# Altium schematic sheet Sheet1.SchDoc
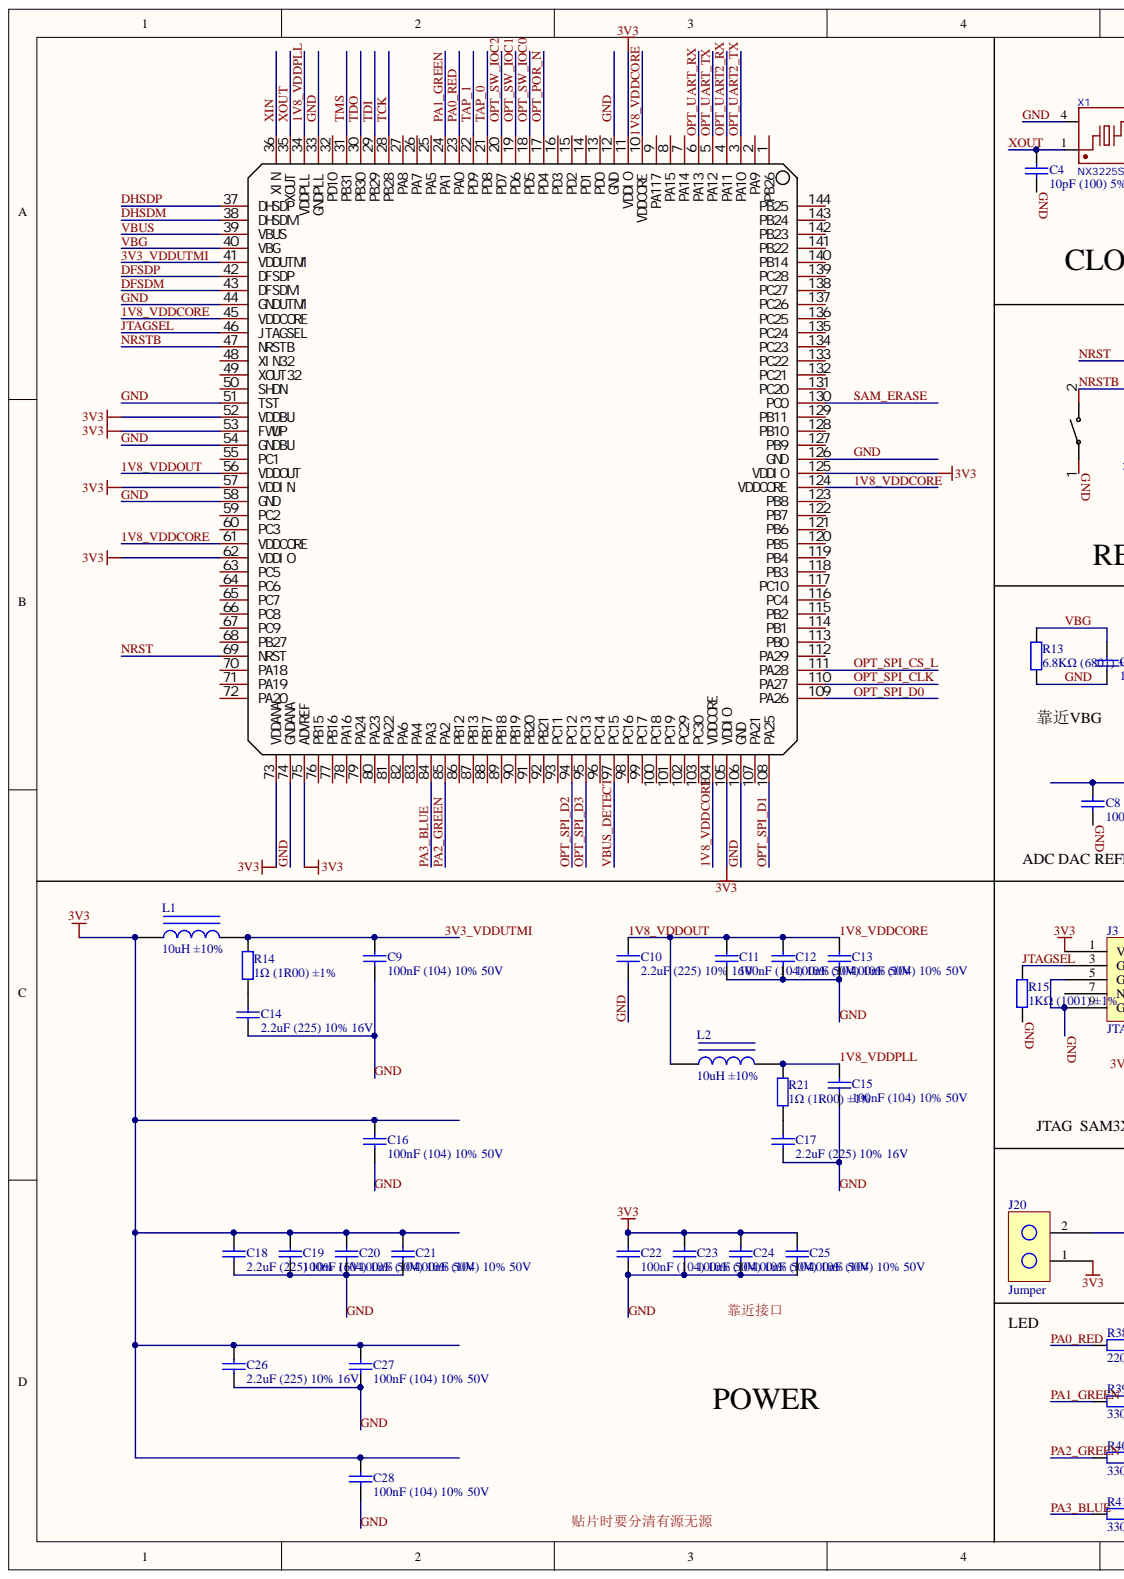
[diagram p0: Sheet1.SchDoc, left]
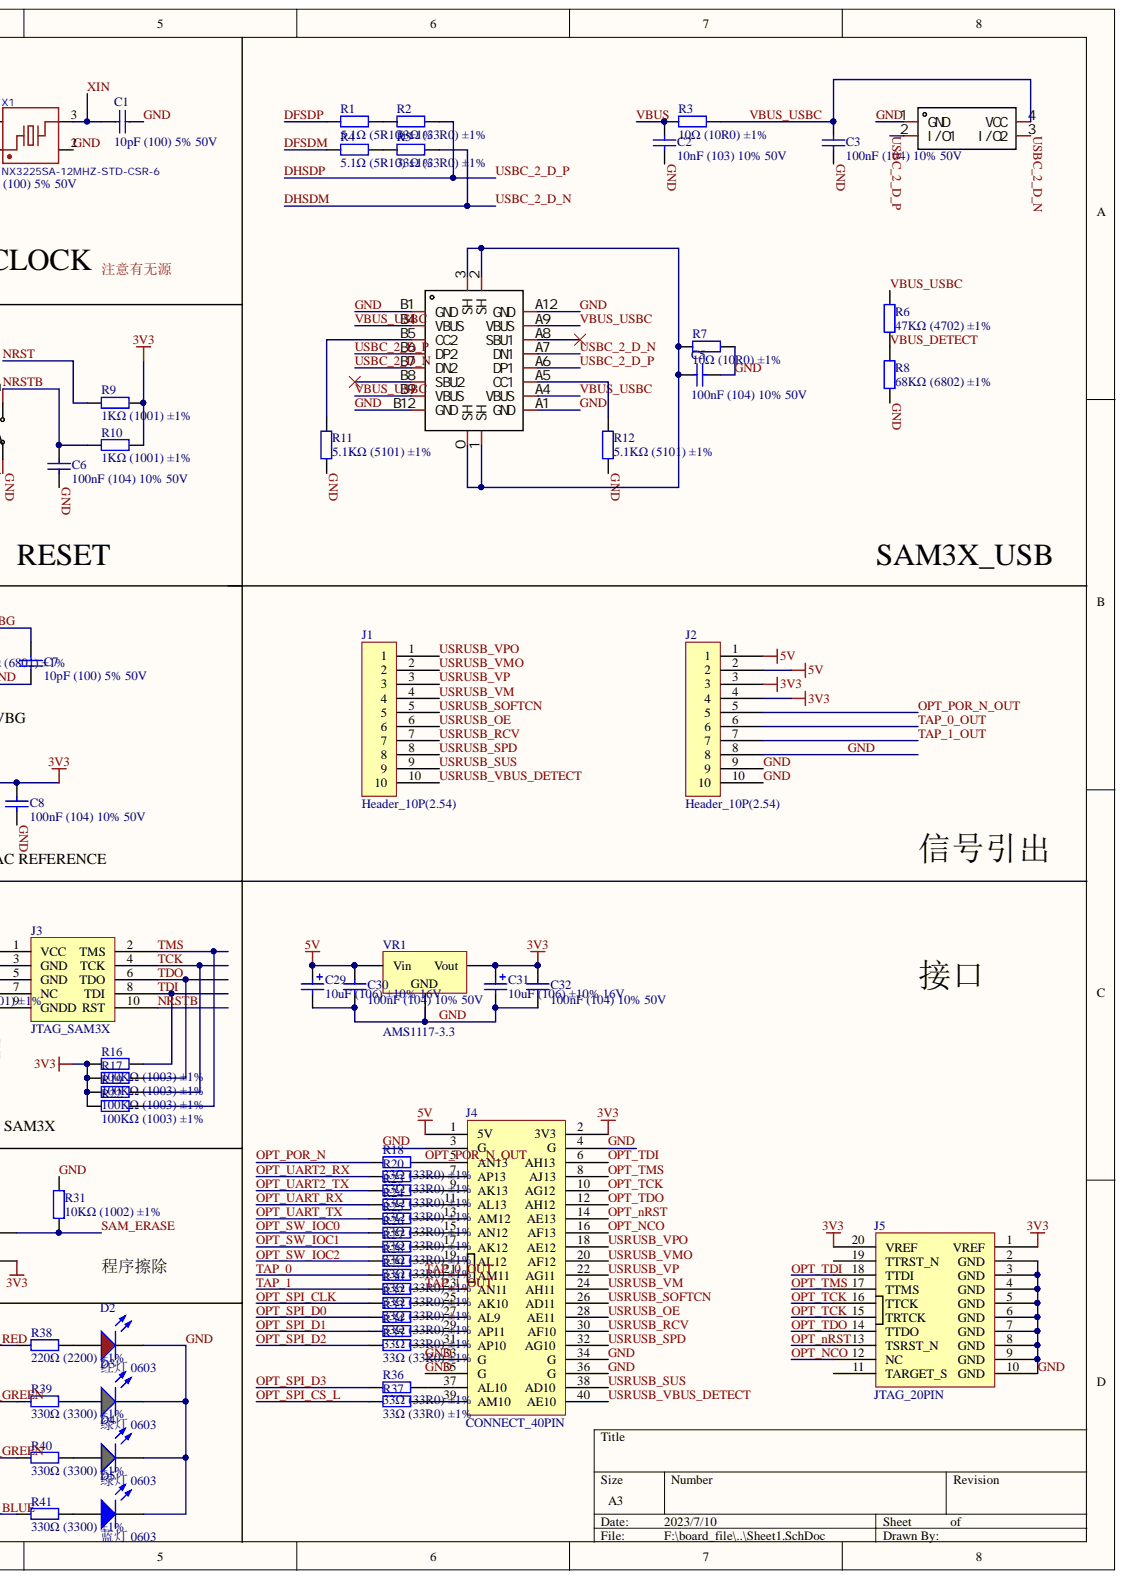
[diagram p1: Sheet1.SchDoc, right]

## Parts
1  USB-TYPE-C-018  [USB-C_SMD-TYPE-C-31-M-12]  (value not drawn)
2  K2-1107ST-A4SW-06  [KEY-SMD_L6.2-W3.6-LS8.0]  (value not drawn)
C1  10pF (100) 5% 50V  [0402_C]
C2  10nF (103) 10% 50V  [0603_C]
C3  100nF (104) 10% 50V  [0603_C]
C4  10pF (100) 5% 50V  [0402_C]
C5  100nF (104) 10% 50V  [0603_C]
C6  100nF (104) 10% 50V  [0603_C]
C7  10pF (100) 5% 50V  [0603_C]
C8  100nF (104) 10% 50V  [0603_C]
C9  100nF (104) 10% 50V  [0603_C]
C10  2.2uF (225) 10% 16V  [0603_C]
C11  100nF (104) 10% 50V  [0603_C]
C12  100nF (104) 10% 50V  [0603_C]
C13  100nF (104) 10% 50V  [0603_C]
C14  2.2uF (225) 10% 16V  [0603_C]
C15  100nF (104) 10% 50V  [0603_C]
C16  100nF (104) 10% 50V  [0603_C]
C17  2.2uF (225) 10% 16V  [0603_C]
C18  2.2uF (225) 10% 16V  [0603_C]
C19  100nF (104) 10% 50V  [0603_C]
C20  100nF (104) 10% 50V  [0603_C]
C21  100nF (104) 10% 50V  [0603_C]
C22  100nF (104) 10% 50V  [0603_C]
C23  100nF (104) 10% 50V  [0603_C]
C24  100nF (104) 10% 50V  [0603_C]
C25  100nF (104) 10% 50V  [0603_C]
C26  2.2uF (225) 10% 16V  [0603_C]
C27  100nF (104) 10% 50V  [0603_C]
C28  100nF (104) 10% 50V  [0603_C]
C29  10uF (106) ±10% 16V  [CASE-A_3216]
C30  100nF (104) 10% 50V  [0603_C]
C31  10uF (106) ±10% 16V  [CASE-A_3216]
C32  100nF (104) 10% 50V  [0603_C]
D1  PRTR5V0U2AX,215  [SOT-143_L2.9-W1.3-P1.92-LS2.3-BL]  (value not drawn)
D2  红灯 0603  Package: 0603  [0603_LED_S1]
D3  绿灯 0603  Package: 0603  [0603_LED_S1]
D4  绿灯 0603  Package: 0603  [0603_LED_S1]
D5  蓝灯 0603  Package: 0603  [0603_LED_S1]
J1  Header_10P(2.54)  [Header_10P(2.54)]
J2  Header_10P(2.54)  [Header_10P(2.54)]
J3  JTAG_SAM3X  [JTAG_SAM3X]
J4  CONNECT_40PIN  [CONNECT_40PIN]  (value not drawn)
J5  JTAG_20PIN  [JTAG_20PIN]
J20  Jumper  [Jumper]
L1  10uH ±10%  [0603_L]
L2  10uH ±10%  [0805_L]
R1  5.1Ω (5R10) ±1%  [0603_R]
R2  33Ω (33R0) ±1%  [0603_R]
R3  10Ω (10R0) ±1%  [0603_R]
R4  5.1Ω (5R10) ±1%  [0603_R]
R5  33Ω (33R0) ±1%  [0603_R]
R6  47KΩ (4702) ±1%  [0603_R]
R7  10Ω (10R0) ±1%  [0603_R]
R8  68KΩ (6802) ±1%  [0603_R]
R9  1KΩ (1001) ±1%  [0805_R]
R10  1KΩ (1001) ±1%  [0805_R]
R11  5.1KΩ (5101) ±1%  [0603_R]
R12  5.1KΩ (5101) ±1%  [0603_R]
R13  6.8KΩ (6801) ±1%  [0603_R]
R14  1Ω (1R00) ±1%  [0603_R]
R15  1KΩ (1001) ±1%  [0805_R]
R16  100KΩ (1003) ±1%  [0603_R]
R17  100KΩ (1003) ±1%  [0603_R]
R18  33Ω (33R0) ±1%  [0603_R]
R19  100KΩ (1003) ±1%  [0603_R]
R20  33Ω (33R0) ±1%  [0603_R]
R21  1Ω (1R00) ±1%  [0603_R]
R22  100KΩ (1003) ±1%  [0603_R]
R23  33Ω (33R0) ±1%  [0603_R]
R24  33Ω (33R0) ±1%  [0603_R]
R25  33Ω (33R0) ±1%  [0603_R]
R26  33Ω (33R0) ±1%  [0603_R]
R27  33Ω (33R0) ±1%  [0603_R]
R28  33Ω (33R0) ±1%  [0603_R]
R29  33Ω (33R0) ±1%  [0603_R]
R30  33Ω (33R0) ±1%  [0603_R]
R31  10KΩ (1002) ±1%  [0603_R]
R32  33Ω (33R0) ±1%  [0603_R]
R33  33Ω (33R0) ±1%  [0603_R]
R34  33Ω (33R0) ±1%  [0603_R]
R35  33Ω (33R0) ±1%  [0603_R]
R36  33Ω (33R0) ±1%  [0603_R]
R37  33Ω (33R0) ±1%  [0603_R]
R38  220Ω (2200) ±1%  [0603_R]
R39  330Ω (3300) ±1%  [0603_R]
R40  330Ω (3300) ±1%  [0603_R]
R41  330Ω (3300) ±1%  [0603_R]
U1  ATSAM3X8EA-AU  [LQFP-144_L20.0-W20.0-P0.50-LS22.0-BL]  (value not drawn)
VR1  AMS1117-3.3  [SOT-223]
X1  NX3225SA-12MHZ-STD-CSR-6  [CRYSTAL-SMD_4P-L3.2-W2.5-BL]

## Nets
3V3: C8.2 C16.2 C18.2 C19.2 C20.2 C21.2 C22.2 C23.2 C24.2 C25.2 C26.2 C27.2 C28.2 C31.1 C32.2 J2.3 J2.4 J3.1(VCC) J4.2(3V3) J5.1(VREF) J5.20(VREF) J20.1 L1.1 R9.2 R10.2 R16.1 R17.1 R19.1 R22.1 U1.11(VDDIO) U1.52(VDDBU) U1.53(FWUP) U1.57(VDDIN) U1.62(VDDIO) U1.73(VDDANA) U1.75(ADVREF) U1.105(VDDIO) U1.125(VDDIO) VR1.2
5V: C29.1 C30.2 J2.1 J2.2 J4.1(5V) VR1.3
1V8_VDDCORE: C10.2 C11.2 C12.2 C13.2 L2.1 U1.10(VDDCORE) U1.45(VDDCORE) U1.56(VDDOUT) U1.61(VDDCORE) U1.104(VDDCORE) U1.124(VDDCORE)
1V8_VDDPLL: C15.2 L2.2 R21.2 U1.34(VDDPLL)
3V3_VDDUTMI: C9.2 L1.2 R14.2 U1.41(VDDUTMI)
DFSDM: R4.1 U1.43(DFSDM)
DFSDP: R1.1 U1.42(DFSDP)
DHSDM: 1.A7(DN1) 1.B7(DN2) D1.3(I/O2) R5.2 U1.38(DHSDM)
DHSDP: 1.A6(DP1) 1.B6(DP2) D1.2(I/O1) R2.2 U1.37(DHSDP)
GND: 1.A1(GND) 1.A12(GND) 1.B1(GND) 1.B12(GND) 2.1 C1.1 C2.1 C3.1 C4.1 C5.1 C6.1 C7.1 C8.1 C9.1 C10.1 C11.1 C12.1 C13.1 C14.1 C15.1 C16.1 C17.1 C18.1 C19.1 C20.1 C21.1 C22.1 C23.1 C24.1 C25.1 C26.1 C27.1 C28.1 C29.2 C30.1 C31.2 C32.1 D1.1(GND) D2.K D3.K D4.K D5.K J2.8 J2.9 J2.10 J3.5(GND) J3.9(GNDD) J4.3(G) J4.4(G) J4.33(G) J4.34(G) J4.35(G) J4.36(G) J5.2(GND) J5.3(GND) J5.4(GND) J5.5(GND) J5.6(GND) J5.7(GND) J5.8(GND) J5.9(GND) J5.10(GND) R7.2 R8.2 R11.1 R12.1 R13.1 R15.1 R31.2 U1.12(GND) U1.33(GNDPLL) U1.44(GNDUTMI) U1.51(TST) U1.54(GNDBU) U1.58(GND) U1.74(GNDANA) U1.106(GND) U1.126(GND) VR1.1 X1.2 X1.4
JTAGSEL: J3.3(GND) R15.2 U1.46(JTAGSEL)
NRST: R9.1 U1.69(NRST)
NRSTB: 2.2 C6.2 J3.10(RST) R10.1 U1.47(NRSTB)
OPT_NCO: J4.16(AF13) J5.12(NC)
OPT_nRST: J4.14(AE13) J5.13(TSRST_N)
OPT_POR_N: R18.1 U1.17(PD4)
OPT_POR_N_OUT: J2.5 J4.5(AN13) R18.2
OPT_SPI_CLK: R32.1 U1.110(PA27)
OPT_SPI_CS_L: R37.1 U1.111(PA28)
OPT_SPI_D0: R33.1 U1.109(PA26)
OPT_SPI_D1: R34.1 U1.108(PA25)
OPT_SPI_D2: R35.1 U1.94(PC12)
OPT_SPI_D3: R36.1 U1.95(PC13)
OPT_SW_IOC0: R26.1 U1.18(PD5)
OPT_SW_IOC1: R27.1 U1.19(PD6)
OPT_SW_IOC2: R28.1 U1.20(PD7)
OPT_TCK: J4.10(AG12) J5.15(TRTCK) J5.16(TTCK)
OPT_TDI: J4.6(AH13) J5.18(TTDI)
OPT_TDO: J4.12(AH12) J5.14(TTDO)
OPT_TMS: J4.8(AJ13) J5.17(TTMS)
OPT_UART2_RX: R20.1 U1.4(PA11)
OPT_UART2_TX: R23.1 U1.3(PA10)
OPT_UART_RX: R24.1 U1.6(PA13)
OPT_UART_TX: R25.1 U1.5(PA12)
PA0_RED: R38.1 U1.23(PA0)
PA1_GREEN: R39.1 U1.24(PA1)
PA2_GREEN: R40.1 U1.85(PA2)
PA3_BLUE: R41.1 U1.84(PA3)
SAM_ERASE: J20.2 R31.1 U1.130(PC0)
TAP_0: R29.1 U1.21(PD8)
TAP_0_OUT: J2.6 J4.21(AM11) R29.2
TAP_1: R30.1 U1.22(PD9)
TAP_1_OUT: J2.7 J4.23(AN11) R30.2
TCK: J3.4(TCK) R19.2 U1.28(PB28)
TDI: J3.8(TDI) R16.2 U1.29(PB29)
TDO: J3.6(TDO) R17.2 U1.30(PB30)
TMS: J3.2(TMS) R22.2 U1.31(PB31)
USRUSB_OE: J1.6 J4.28(AE11)
USRUSB_RCV: J1.7 J4.30(AF10)
USRUSB_SOFTCN: J1.5 J4.26(AD11)
USRUSB_SPD: J1.8 J4.32(AG10)
USRUSB_SUS: J1.9 J4.38(AD10)
USRUSB_VBUS_DETECT: J1.10 J4.40(AE10)
USRUSB_VM: J1.4 J4.24(AH11)
USRUSB_VMO: J1.2 J4.20(AF12)
USRUSB_VP: J1.3 J4.22(AG11)
USRUSB_VPO: J1.1 J4.18(AE12)
VBG: C7.2 R13.2 U1.40(VBG)
VBUS: C2.2 R3.1 U1.39(VBUS)
VBUS_DETECT: R6.1 R8.1 U1.97(PC15)
VBUS_USBC: 1.A4(VBUS) 1.A9(VBUS) 1.B4(VBUS) 1.B9(VBUS) C3.2 D1.4(VCC) R3.2 R6.2
XIN: C1.2 U1.36(XIN) X1.3
XOUT: C4.2 U1.35(XOUT) X1.1
Net1_0: 1.0(SH) 1.1(SH) 1.2(SH) 1.3(SH) C5.2 R7.1
Net1_A5: 1.A5(CC1) R12.2
Net1_B5: 1.B5(CC2) R11.2
NetC14_2: C14.2 R14.1
NetC17_2: C17.2 R21.1
NetD2_A: D2.A R38.2
NetD3_A: D3.A R39.2
NetD4_A: D4.A R40.2
NetD5_A: D5.A R41.2
NetJ4_7: J4.7(AP13) R20.2
NetJ4_9: J4.9(AK13) R23.2
NetJ4_11: J4.11(AL13) R24.2
NetJ4_13: J4.13(AM12) R25.2
NetJ4_15: J4.15(AN12) R26.2
NetJ4_17: J4.17(AK12) R27.2
NetJ4_19: J4.19(AL12) R28.2
NetJ4_25: J4.25(AK10) R32.2
NetJ4_27: J4.27(AL9) R33.2
NetJ4_29: J4.29(AP11) R34.2
NetJ4_31: J4.31(AP10) R35.2
NetJ4_37: J4.37(AL10) R36.2
NetJ4_39: J4.39(AM10) R37.2
NetR1_2: R1.2 R2.1
NetR4_2: R4.2 R5.1

Not connected: 1 A8(SBU1) B8(SBU2); J3 7(NC); J5 11(TARGET_S) 19(TTRST_N); U1 1(PB26) 2(PA9) 7(PA14) 8(PA15) 9(PA117) 13(PD0) 14(PD1) 15(PD2) 16(PD3) 25(PA5) 26(PA7) 27(PA8) 32(PD10) 48(XIN32) 49(XOUT32) 50(SHDN) 55(PC1) 59(PC2) 60(PC3) 63(PC5) 64(PC6) 65(PC7) 66(PC8) 67(PC9) 68(PB27) 70(PA18) 71(PA19) 72(PA20) 76(PB15) 77(PB16) 78(PA16) 79(PA24) 80(PA23) 81(PA22) 82(PA6) 83(PA4) 86(PB12) 87(PB13) 88(PB17) 89(PB18) 90(PB19) 91(PB20) 92(PB21) 93(PC11) 96(PC14) 98(PC16) 99(PC17) 100(PC18) 101(PC19) 102(PC29) 103(PC30) 107(PA21) 112(PA29) 113(PB0) 114(PB1) 115(PB2) 116(PC4) 117(PC10) 118(PB3) 119(PB4) 120(PB5) 121(PB6) 122(PB7) 123(PB8) 127(PB9) 128(PB10) 129(PB11) 131(PC20) 132(PC21) 133(PC22) 134(PC23) 135(PC24) 136(PC25) 137(PC26) 138(PC27) 139(PC28) 140(PB14) 141(PB22) 142(PB23) 143(PB24) 144(PB25)

## Library data of the parts
1 = USB-TYPE-C-018: Designer: U?; Component Kind: Standard; Device: USB-TYPE-C-018; Library Name: Schlib1.SchLib; Symbol: USB-TYPE-C-018
2 = K2-1107ST-A4SW-06: Designer: U?; Component Kind: Standard; Device: K2-1107ST-A4SW-06; Library Name: Schlib1.SchLib; Symbol: K2-1107ST-A4SW-06
C1 C4 = 0402 10pF (100) 5% 50V: 贴片电容; Component Kind: Standard; ComponentLink1Description: 供应商链接; ComponentLink1URL: http://www.szlcsc.com/product/details_33914.html; Library Name: Cap.SchLib; Package: 0402; Supplier: LC; SuppliersPartNumber: C32949
C2 = 0603 10nF (103) 10% 50V: 贴片电容; Component Kind: Standard; ComponentLink1Description: 供应商链接; ComponentLink1URL: http://www.szlcsc.com/product/details_58143.html; Library Name: Cap.SchLib; Package: 0603; Supplier: LC; SuppliersPartNumber: C57112
C3 C5 C6 C8 C9 C11-C13 C15 C16 C19-C25 C27 C28 C30 C32 = 0603 100nF (104) 10% 50V: 贴片电容; Component Kind: Standard; ComponentLink1Description: 供应商链接; ComponentLink1URL: http://www.szlcsc.com/product/details_15331.html; Library Name: Cap.SchLib; Package: 0603; Supplier: LC; SuppliersPartNumber: C14663
C7 = 0603 10pF (100) 5% 50V: 贴片电容; Component Kind: Standard; ComponentLink1Description: 供应商链接; ComponentLink1URL: http://www.szlcsc.com/product/details_1986.html; Library Name: Cap.SchLib; Package: 0603; Supplier: LC; SuppliersPartNumber: C1634
C10 C14 C17 C18 C26 = 0603 2.2uF (225) 10% 16V: 贴片电容; Component Kind: Standard; ComponentLink1Description: 供应商链接; ComponentLink1URL: http://www.szlcsc.com/product/details_24366.html; Library Name: Cap.SchLib; Package: 0603; Supplier: LC; SuppliersPartNumber: C23630
C29 C31 = 3216 10uF (106) 10% 16V: 钽电容; Component Kind: Standard; ComponentLink1Description: 供应商链接; ComponentLink1URL: http://www.szlcsc.com/product/details_7643.html; Library Name: Cap.SchLib; Package: 3216; Supplier: LC; SuppliersPartNumber: C7171
D1 = PRTR5V0U2AX,215: Manufacturer: Nexperia(安世); Add into BOM: yes; Component Kind: Standard; Convert to PCB: yes; Datasheet: https://atta.szlcsc.com/upload/public/pdf/source/20191113/C455074_32D1BDE7CE1566807082B5BF9BD48CB7.pdf; Device: PRTR5V0U2AX,215; JLCPCB Part Class: Extended Part; LCSC Part Name: PRTR5V0U2AX,215; Library Name: Schlib1.SchLib; Manufacturer Part: PRTR5V0U2AX,215; Name: PRTR5V0U2AX,215; PCB3D: SOT-143_L2.9-W1.3-P1.92-LS2.3-BL; Supplier: LCSC; Supplier Footprint: SOT143; Supplier Part: C455074; Symbol: PRTR5V0U2AX,215
D2 = 0603 红灯: 发光二极管; Component Kind: Standard; ComponentLink1Description: 供应商链接; ComponentLink1URL: http://www.szlcsc.com/product/details_2659.html; Library Name: LED.SchLib; Supplier: LC; SuppliersPartNumber: C2286
D3 D4 = 0603 绿灯: 发光二极管; Component Kind: Standard; ComponentLink1Description: 供应商链接; ComponentLink1URL: http://www.szlcsc.com/product/details_73152.html; Library Name: LED.SchLib; Supplier: LC; SuppliersPartNumber: C72043
D5 = 0603 蓝灯: 发光二极管; Component Kind: Standard; ComponentLink1Description: 供应商链接; ComponentLink1URL: http://www.szlcsc.com/product/details_73150.html; Library Name: LED.SchLib; Supplier: LC; SuppliersPartNumber: C72041
L1 = 0603 10uH 10%: 贴片电感; Component Kind: Standard; ComponentLink1Description: 供应商链接; ComponentLink1URL: http://www.szlcsc.com/product/details_1387.html; Library Name: IUD.SchLib; Package: 0603; Supplier: LC; SuppliersPartNumber: C1035
L2 = 0805 10uH 10%: 贴片电感; Component Kind: Standard; ComponentLink1Description: 供应商链接; ComponentLink1URL: http://www.szlcsc.com/product/details_1398.html; Library Name: IUD.SchLib; Package: 0805; Supplier: LC; SuppliersPartNumber: C1046
R1 R4 = 0603 5.1Ω (5R10) 1%: 贴片电阻; Component Kind: Standard; ComponentLink1Description: 供应商链接; ComponentLink1URL: http://www.szlcsc.com/product/details_25940.html; Library Name: RES.SchLib; Package: 0603; Supplier: LC; SuppliersPartNumber: C25197
R2 R5 R18 R20 R23-R30 R32-R37 = 0603 33Ω (33R0) 1%: 贴片电阻; Component Kind: Standard; ComponentLink1Description: 供应商链接; ComponentLink1URL: http://www.szlcsc.com/product/details_23867.html; Library Name: RES.SchLib; Package: 0603; Supplier: LC; SuppliersPartNumber: C23140
R3 R7 = 0603 10Ω (10R0) 1%: 贴片电阻; Component Kind: Standard; ComponentLink1Description: 供应商链接; ComponentLink1URL: http://www.szlcsc.com/product/details_23586.html; Library Name: RES.SchLib; Package: 0603; Supplier: LC; SuppliersPartNumber: C22859
R6 = 0603 47KΩ (4702) 1%: 贴片电阻; Component Kind: Standard; ComponentLink1Description: 供应商链接; ComponentLink1URL: http://www.szlcsc.com/product/details_26562.html; Library Name: RES.SchLib; Package: 0603; Supplier: LC; SuppliersPartNumber: C25819
R8 = 0603 68KΩ (6802) 1%: 贴片电阻; Component Kind: Standard; ComponentLink1Description: 供应商链接; ComponentLink1URL: http://www.szlcsc.com/product/details_23958.html; Library Name: RES.SchLib; Package: 0603; Supplier: LC; SuppliersPartNumber: C23231
R9 R10 R15 = 0805 1KΩ (1001) 1%: 贴片电阻; Component Kind: Standard; ComponentLink1Description: 供应商链接; ComponentLink1URL: http://www.szlcsc.com/product/details_18201.html; Library Name: RES.SchLib; Package: 0805; Supplier: LC; SuppliersPartNumber: C17513
R11 R12 = 0603 5.1KΩ (5101) 1%: 贴片电阻; Component Kind: Standard; ComponentLink1Description: 供应商链接; ComponentLink1URL: http://www.szlcsc.com/product/details_23913.html; Library Name: RES.SchLib; Package: 0603; Supplier: LC; SuppliersPartNumber: C23186
R13 = 0603 6.8KΩ (6801) 1%: 贴片电阻; Component Kind: Standard; ComponentLink1Description: 供应商链接; ComponentLink1URL: http://www.szlcsc.com/product/details_23939.html; Library Name: RES.SchLib; Package: 0603; Supplier: LC; SuppliersPartNumber: C23212
R14 R21 = 0603 1Ω (1R00) 1%: 贴片电阻; Component Kind: Standard; ComponentLink1Description: 供应商链接; ComponentLink1URL: http://www.szlcsc.com/product/details_23663.html; Library Name: RES.SchLib; Package: 0603; Supplier: LC; SuppliersPartNumber: C22936
R16 R17 R19 R22 = 0603 100KΩ (1003) 1%: 贴片电阻; Component Kind: Standard; ComponentLink1Description: 供应商链接; ComponentLink1URL: http://www.szlcsc.com/product/details_26546.html; Library Name: RES.SchLib; Package: 0603; Supplier: LC; SuppliersPartNumber: C25803
R31 = 0603 10KΩ (1002) 1%: 贴片电阻; Component Kind: Standard; ComponentLink1Description: 供应商链接; ComponentLink1URL: http://www.szlcsc.com/product/details_26547.html; Library Name: RES.SchLib; Package: 0603; Supplier: LC; SuppliersPartNumber: C25804
R38 = 0603 220Ω (2200) 1%: 贴片电阻; Component Kind: Standard; ComponentLink1Description: 供应商链接; ComponentLink1URL: http://www.szlcsc.com/product/details_23689.html; Library Name: RES.SchLib; Package: 0603; Supplier: LC; SuppliersPartNumber: C22962
R39-R41 = 0603 330Ω (3300) 1%: 贴片电阻; Component Kind: Standard; ComponentLink1Description: 供应商链接; ComponentLink1URL: http://www.szlcsc.com/product/details_23865.html; Library Name: RES.SchLib; Package: 0603; Supplier: LC; SuppliersPartNumber: C23138
U1 = ATSAM3X8EA-AU: Manufacturer: MICROCHIP(美国微芯); ADC (Bit): 12bit; Add into BOM: yes; CPU Core: ARM Cortex-M3; CPU Maximum Speed: 84MHz; Direct Memory Access: 有; GPIO Ports Number: 103; Operating Voltage Range: 1.62V~3.6V; Peripheral/Function: PWM；PWM; Program Memory Type: FLASH; Program Storage Size: 512KB; RAM Size: 100KB; CCP Capture/Compare: -; communication protocol: -; Component Kind: Standard; Convert to PCB: yes; DAC (Bit): 12bit; Datasheet: https://atta.szlcsc.com/upload/public/pdf/source/20160723/1469258987896.pdf; Device: ATSAM3X8EA-AU; EEPROM: -; Infrared Data Association: 有; Internal Comparator: -; Internal Oscillator: 有; JLCPCB Part Class: Extended Part; LCSC Part Name: ARM Cortex-M3 84MHz; Library Name: Schlib1.SchLib; Low-Voltage Detect: 有; Manufacturer Part: ATSAM3X8EA-AU; Name: ATSAM3X8EA-AU; Operating Temperature Range: -40℃~+85℃; PCB3D: LQFP-144_L20.0-W20.0-P0.50-LS22.0-BL; PWM (Bit): -; Real-Time Clock: -; Secure Digital Input and Output: -; Supplier: LCSC; Supplier Footprint: LQFP-144(20x20); Supplier Part: C79305; Symbol: ATSAM3X8EA-AU; Universal Serial Bus: 有; Watchdog: 有
VR1 = SOT-223 AMS1117-3.3: 低压差线性稳压(LDO); Component Kind: Standard; ComponentLink1Description: 供应商链接; ComponentLink1URL: http://www.szlcsc.com/product/details_6652.html; Library Name: LDO.SchLib; Package: SOT-223; Supplier: LC; SuppliersPartNumber: C6186
J1 J2 = Header_10P(2.54): Component Kind: Standard; Library Name: Schlib1.SchLib
J3 = JTAG_SAM3X: Component Kind: Standard; Library Name: Schlib1.SchLib
J4 = CONNECT_40PIN: Component Kind: Standard; Library Name: Schlib1.SchLib
J5 = JTAG_20PIN: Component Kind: Standard; Library Name: Schlib1.SchLib
J20 = Jumper: Component Kind: Standard; Library Name: Schlib1.SchLib
X1 = NX3225SA-12MHZ-STD-CSR-6: Component Kind: Standard; Library Name: Schlib1.SchLib
